# Altium schematic sheet DWM1000ExpBoard.SchDoc(DWM1000ExpBoard)
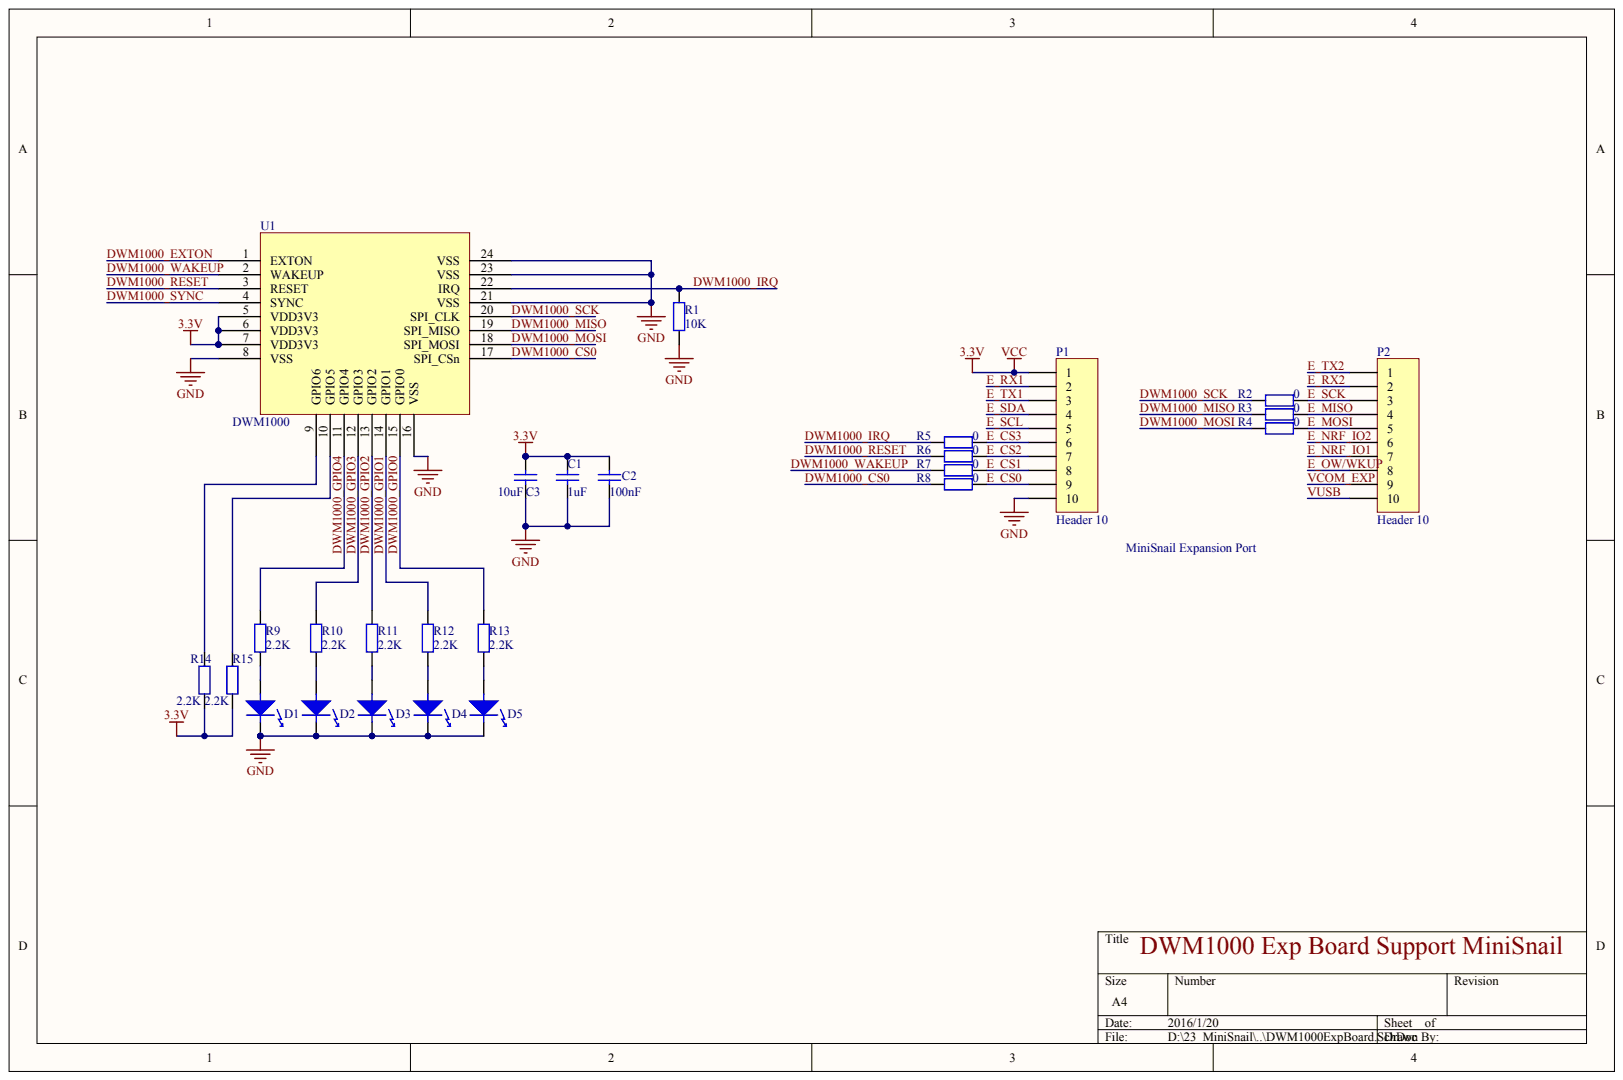
[diagram p0: DWM1000ExpBoard.SchDoc]

## Parts
C1  value 1uF  (hidden comment: Cap)
C2  value 100nF  (hidden comment: Cap)
C3  value 10uF  (hidden comment: Cap)
D1  LG Q971-KN-1  (value not drawn)
D2  LG Q971-KN-1  (value not drawn)
D3  LG Q971-KN-1  (value not drawn)
D4  LG Q971-KN-1  (value not drawn)
D5  LG Q971-KN-1  (value not drawn)
P1  Header 10
P2  Header 10
R1  value 10K  (hidden comment: 2.2K)
R2  value 0  (hidden comment: 2.2K)
R3  value 0  (hidden comment: 2.2K)
R4  value 0  (hidden comment: 2.2K)
R5  value 0  (hidden comment: 2.2K)
R6  value 0  (hidden comment: 2.2K)
R7  value 0  (hidden comment: 2.2K)
R8  value 0  (hidden comment: 2.2K)
R9  2.2K
R10  2.2K
R11  2.2K
R12  2.2K
R13  2.2K
R14  2.2K
R15  2.2K
U1  DWM1000

## Nets
3.3V: C1.2 C2.2 C3.2 P1.1 R14.2 R15.2 U1.5(VDD3V3) U1.6(VDD3V3) U1.7(VDD3V3)
GND: C1.1 C2.1 C3.1 D1.2 D2.2 D3.2 D4.2 D5.2 P1.10 R1.1 U1.8(VSS) U1.16(VSS) U1.21(VSS) U1.23(VSS) U1.24(VSS)
DWM1000_CS0: R8.2 U1.17(SPI_CSn)
DWM1000_EXTON: U1.1(EXTON)
DWM1000_GPIO0: R13.1 U1.15(GPIO0)
DWM1000_GPIO1: R12.1 U1.14(GPIO1)
DWM1000_GPIO2: R11.1 U1.13(GPIO2)
DWM1000_GPIO3: R10.1 U1.12(GPIO3)
DWM1000_GPIO4: R9.1 U1.11(GPIO4)
DWM1000_IRQ: R1.2 R5.2 U1.22(IRQ)
DWM1000_MISO: R3.2 U1.19(SPI_MISO)
DWM1000_MOSI: R4.2 U1.18(SPI_MOSI)
DWM1000_RESET: R6.2 U1.3(RESET)
DWM1000_SCK: R2.2 U1.20(SPI_CLK)
DWM1000_SYNC: U1.4(SYNC)
DWM1000_WAKEUP: R7.2 U1.2(WAKEUP)
E_CS0: P1.9 R8.1
E_CS1: P1.8 R7.1
E_CS2: P1.7 R6.1
E_CS3: P1.6 R5.1
E_MISO: P2.4 R3.1
E_MOSI: P2.5 R4.1
E_NRF_IO1: P2.7
E_NRF_IO2: P2.6
E_OW/WKUP: P2.8
E_RX1: P1.2
E_RX2: P2.2
E_SCK: P2.3 R2.1
E_SCL: P1.5
E_SDA: P1.4
E_TX1: P1.3
E_TX2: P2.1
VCOM_EXP: P2.9
VUSB: P2.10
NetD1_1: D1.1 R9.2
NetD2_1: D2.1 R10.2
NetD3_1: D3.1 R11.2
NetD4_1: D4.1 R12.2
NetD5_1: D5.1 R13.2
NetR14_1: R14.1 U1.9(GPIO6)
NetR15_1: R15.1 U1.10(GPIO5)

## Library data of the parts
D1-D5 = (no symbol name): Manufacturer: OSRAM Opto Semiconductors Inc; Color: Green; Wavelength - Dominant: 570nm; Wavelength - Peak: 572nm; Millicandela Rating: 10mcd; Voltage - Forward (Vf) Typ: 2.2V; Current - Test: 20mA; Lens Type: Diffused; Lens Style/Size: Rectangle with Flat Top, 1mm x 0.8mm; Supplier 1: Digi-Key; Manufacturer Part Number: LG Q971-KN-1; RoHS: RoHS Compliant; Category: Optoelectronics; Stock 1: 44000; Pricing 1: 4000=0.03632 (USD); Packaging: Tape & Reel (TR); : 160°|NAME=Viewing Angle; Package / Case: 0603 (1608 Metric); Size / Dimension: 1.60mm L x 0.80mm W; Height: 0.80mm; Mounting Type: Surface Mount; Luminous Flux @ Current - Test: -; ComponentLink1URL: http://catalog.osram-os.com/catalogue/catalogue.do;jsessionid=DE4D071D0D2758CC117C711BF848053E?act=downloadFile&favOid=0200000600005069000100b6; ComponentLink1Description: http://catalog.osram-os.com/catalogue/catalogue.do;jsessionid=DE4D071D0D2758CC117C711BF848053E?act=downloadFile&favOid=0200000600005069000100b6
U1 = (no symbol name): Manufacturer: Decawave Limited; Frequency: 3.5GHz ~ 6.5GHz; Data Rate: 6.8Mbps; Serial Interfaces: SPI; Antenna Type: Integrated, Chip; Voltage - Supply: 2.8 V ~ 3.6 V; Current - Receiving: 64mA; Current - Transmitting: 31mA; Supplier 1: Digi-Key; Manufacturer Part Number: DWM1000; RoHS: RoHS Compliant; Category: RF/IF and RFID; Stock 1: 1028; Pricing 1: 1=32.16, 10=26.704, 100=24.24, 250=22.51296 (USD); Packaging: Cut Tape (CT); RF Family/Standard: 802.15.4; Protocol: -; Modulation: -; Power - Output: -; Sensitivity: -; Memory Size: -; Mounting Type: Surface Mount; Operating Temperature: -40°C ~ 85°C; Package / Case: Module; ComponentLink1URL: http://www.decawave.com/sites/default/files/product-pdf/dwm1000-product-brief.pdf; ComponentLink1Description: http://www.decawave.com/sites/default/files/product-pdf/dwm1000-product-brief.pdf; Supplier Part Number 1: 1479-1002-1-ND
C1-C3 P1 P2 R1-R15 = (no symbol name): LatestRevisionDate: 17-Jul-2002; LatestRevisionNote: Re-released for DXP Platform.; Publisher: Altium Limited
  C1-C3: Published: 8-Jun-2000; PackageReference: RAD-0.3; PackageDescription: Radial Cap, Thru-Hole; 2 Leads; 0.3 in Pin Spacing
  R1-R8: Published: 8-Jun-2000; PackageReference: AXIAL-0.4; PackageDescription: Axial Device, Thru-Hole; 2 Leads; 0.4 in Pin Spacing
  R9-R15: Published: 8-Jun-2000; PackageReference: AXIAL-0.4; PackageDescription: Axial Device, Thru-Hole; 2 Leads; 0.4 in Pin Spacing; Value: 2.2K
